# Altium schematic sheet UsbC_sink.SchDoc(UsbC_sink)
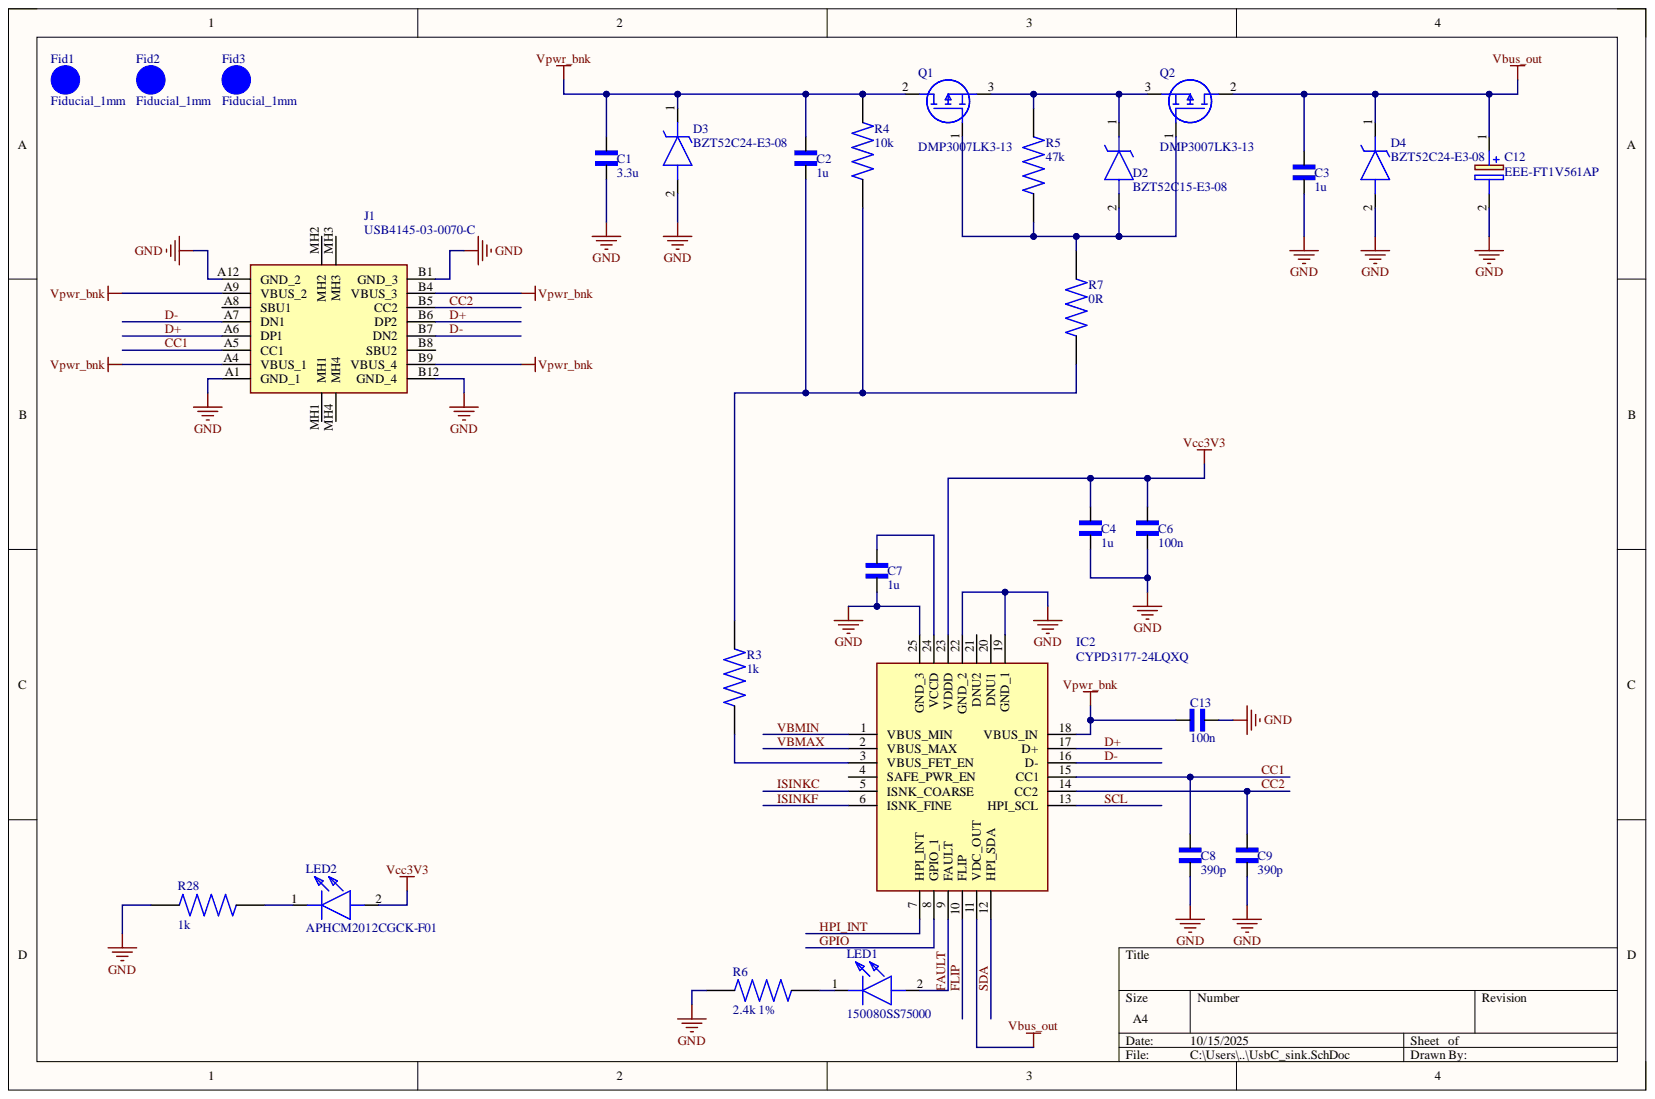
[diagram p0: UsbC_sink.SchDoc]

## Parts
C1  3.3u  [cap_1206]
C2  1u  [cap_1206]
C3  1u  [cap_1206]
C4  1u  [cap_1206]
C6  100n  [cap_1206]
C7  1u  [cap_1206]
C8  390p  [cap_1206]
C9  390p  [cap_1206]
C12  EEE-FT1V561AP  [EEE0JA152UAP]
C13  100n  [cap_1206]
D2  BZT52C15-E3-08  [SOD3716X135N]
D3  BZT52C24-E3-08  [BZT52C24E308]
D4  BZT52C24-E3-08  [BZT52C24E308]
Fid1  Fiducial_1mm  [Fiducial_1mm-Footprint-1]  (no BOM)
Fid2  Fiducial_1mm  [Fiducial_1mm-Footprint-1]  (no BOM)
Fid3  Fiducial_1mm  [Fiducial_1mm-Footprint-1]  (no BOM)
IC2  CYPD3177-24LQXQ  [QFN50P400X400X60-25N-D]
J1  USB4145-03-0070-C  [USB4145030070C]
LED1  150080SS75000  [150080SS75000]
LED2  APHCM2012CGCK-F01  [LEDC2012X50N]
Q1  DMP3007LK3-13  [DMP3007LK313]
Q2  DMP3007LK3-13  [DMP3007LK313]
R3  1k  [res_1206]
R4  10k  [res_1206]
R5  47k  [res_1206]
R6  2.4k 1%  [res_1206]
R7  0R  [res_1206]
R28  1k  [res_1206]

## Nets
GND: C1.1 C3.1 C4.1 C6.1 C7.1 C8.1 C9.1 C12.2 C13.1 D3.2 D4.2 IC2.19(GND_1) IC2.22(GND_2) IC2.25(GND_3) J1.A1(GND_1) J1.A12(GND_2) J1.B1(GND_3) J1.B12(GND_4) R6.2 R28.2
Vbus_out: C3.2 C12.1 D4.1 IC2.11(VDC_OUT) Q2.2
Vcc3V3: C4.2 C6.2 IC2.23(VDDD) LED2.2
Vpwr_bnk: C1.2 C2.2 C13.2 D3.1 IC2.18(VBUS_IN) J1.A4(VBUS_1) J1.A9(VBUS_2) J1.B4(VBUS_3) J1.B9(VBUS_4) Q1.2 R4.2
CC1: C8.2 IC2.15(CC1) J1.A5(CC1)
CC2: C9.2 IC2.14(CC2) J1.B5(CC2)
D+: IC2.17(D+) J1.A6(DP1) J1.B6(DP2)
D-: IC2.16(D-) J1.A7(DN1) J1.B7(DN2)
FAULT: IC2.9(FAULT) LED1.2
FLIP: IC2.10(FLIP)
GPIO: IC2.8(GPIO_1)
HPI_INT: IC2.7(HPI_INT)
ISINKC: IC2.5(ISNK_COARSE)
ISINKF: IC2.6(ISNK_FINE)
SCL: IC2.13(HPI_SCL)
SDA: IC2.12(HPI_SDA)
VBMAX: IC2.2(VBUS_MAX)
VBMIN: IC2.1(VBUS_MIN)
NetC2_1: C2.1 R3.2 R4.1 R7.1
NetC7_2: C7.2 IC2.24(VCCD)
NetD2_1: D2.1 Q1.3 Q2.3 R5.2
NetD2_2: D2.2 Q1.1 Q2.1 R5.1 R7.2
NetIC2_3: IC2.3(VBUS_FET_EN) R3.1
NetLED1_1: LED1.1 R6.1
NetLED2_1: LED2.1 R28.1

Not connected: IC2 4(SAFE_PWR_EN) 20(DNU1) 21(DNU2); J1 A8(SBU1) B8(SBU2) MH1 MH2 MH3 MH4

## Library data of the parts
C1-C4 C6-C9 C13 = cap_1206: Component Kind: Standard; Library Name: cap_1206.IntLib
C12 = EEE-FT1V561AP: Capacitor Polarised; Manufacturer_Name: Panasonic; Component Kind: Standard; Datasheet Link: http://industrial.panasonic.com/cdbs/www-data/pdf/RDE0000/ABA0000C1240.pdf; Height: 12mm; Manufacturer_Part_Number: EEE-FT1V561AP; Mouser Part Number: 667-EEE-FT1V561AP; Mouser Price/Stock: https://www.mouser.co.uk/ProductDetail/Panasonic/EEE-FT1V561AP?qs=CMJjuEs1%252BuG94FAKPKhAsA%3D%3D
D2 = BZT52C15-E3-08: Zener Diode; Manufacturer_Name: Vishay; Arrow Part Number: BZT52C15-E3-08; Arrow Price/Stock: https://www.arrow.com/en/products/bzt52c15-e3-08/vishay?utm_currency=USD&region=nac; Component Kind: Standard; Datasheet Link: https://www.vishay.com/docs/85760/bzt52.pdf; Height: 1.35mm; Manufacturer_Part_Number: BZT52C15-E3-08; Mouser Part Number: 78-BZT52C15-E3-08; Mouser Price/Stock: https://www.mouser.co.uk/ProductDetail/Vishay-Semiconductors/BZT52C15-E3-08?qs=KP8Io%2FXyE5T2xU7%252Bcte76g%3D%3D
D3 D4 = BZT52C24-E3-08: Zener Diode; Manufacturer_Name: Vishay; Arrow Part Number: BZT52C24-E3-08; Arrow Price/Stock: https://www.arrow.com/en/products/bzt52c24-e3-08/vishay; Component Kind: Standard; Datasheet Link: https://www.arrow.com/en/products/bzt52c24-e3-08/vishay; Height: 1.35mm; Manufacturer_Part_Number: BZT52C24-E3-08; Mouser Part Number: 78-BZT52C24-E3-08; Mouser Price/Stock: https://www.mouser.co.uk/ProductDetail/Vishay-Semiconductors/BZT52C24-E3-08?qs=KP8Io%2FXyE5S5oCYkORUCQA%3D%3D
Fid1-Fid3 = CMP-00017-6: 1mm fiducial marker; Library Name: AZZURRODIGITALE MACHINE INTEGRATION SRL
IC2 = CYPD3177-24LQXQ: Integrated Circuit; Manufacturer_Name: Infineon; Arrow Part Number: CYPD3177-24LQXQ; Arrow Price/Stock: https://www.arrow.com/en/products/cypd3177-24lqxq/infineon-technologies-ag?utm_currency=USD&region=nac; Component Kind: Standard; Datasheet Link: https://au.mouser.com/datasheet/2/196/CYPR_S_A0012903526_1-3004964.pdf; Height: 0.6mm; Manufacturer_Part_Number: CYPD3177-24LQXQ; Mouser Part Number: 727-CYPD3177-24LQXQ; Mouser Price/Stock: https://www.mouser.co.uk/ProductDetail/Infineon-Technologies/CYPD3177-24LQXQ?qs=PzGy0jfpSMvR3aDH5AdWfA%3D%3D
J1 = USB4145-03-0070-C: Connector; Manufacturer_Name: GCT (GLOBAL CONNECTOR TECHNOLOGY); Component Kind: Standard; Datasheet Link: https://gct.co/files/drawings/usb4145.pdf; Height: 7.66mm; Manufacturer_Part_Number: USB4145-03-0070-C; Mouser Part Number: 640-USB4145-3-0070-C; Mouser Price/Stock: https://www.mouser.co.uk/ProductDetail/GCT/USB4145-03-0070-C?qs=vvQtp7zwQdNoT6Ti9aciDw%3D%3D
LED1 = 150080SS75000: LED; Manufacturer_Name: Wurth Elektronik; Component Kind: Standard; Datasheet Link: https://www.we-online.com/components/products/datasheet/150080SS75000.pdf; Height: 0.8mm; Manufacturer_Part_Number: 150080SS75000; Mouser Part Number: 710-150080SS75000; Mouser Price/Stock: https://www.mouser.co.uk/ProductDetail/Wurth-Elektronik/150080SS75000?qs=LlUlMxKIyB2zzpiaOzrUdw%3D%3D
LED2 = APHCM2012CGCK-F01: LED; Manufacturer_Name: Kingbright; Arrow Part Number: APHCM2012CGCK-F01; Arrow Price/Stock: https://www.arrow.com/en/products/aphcm2012cgck-f01/kingbright?region=nac; Component Kind: Standard; Datasheet Link: http://www.kingbrightusa.com/images/catalog/SPEC/APHCM2012CGCK-F01.pdf; Height: 0.5mm; Manufacturer_Part_Number: APHCM2012CGCK-F01; Mouser Part Number: 604-APHCM2012CGCK; Mouser Price/Stock: https://www.mouser.co.uk/ProductDetail/Kingbright/APHCM2012CGCK-F01?qs=qqKal61G0KznDDeOGiLi0g%3D%3D
Q1 Q2 = DMP3007LK3-13: MOSFET (P-Channel); Manufacturer_Name: Diodes Incorporated; Component Kind: Standard; Datasheet Link: https://www.diodes.com/assets/Datasheets/DMP3007LK3.pdf; Height: 2.39mm; Manufacturer_Part_Number: DMP3007LK3-13; Mouser Part Number: 621-DMP3007LK3-13; Mouser Price/Stock: https://www.mouser.co.uk/ProductDetail/Diodes-Incorporated/DMP3007LK3-13?qs=17u8i%2FzlE8%252BiIxnE0yV1ZQ%3D%3D
R3-R7 R28 = res_1206: Component Kind: Standard; Library Name: cap_1206.IntLib
